# Altium schematic sheet Pin_Expansion.SchDoc(Pin_Expansion)
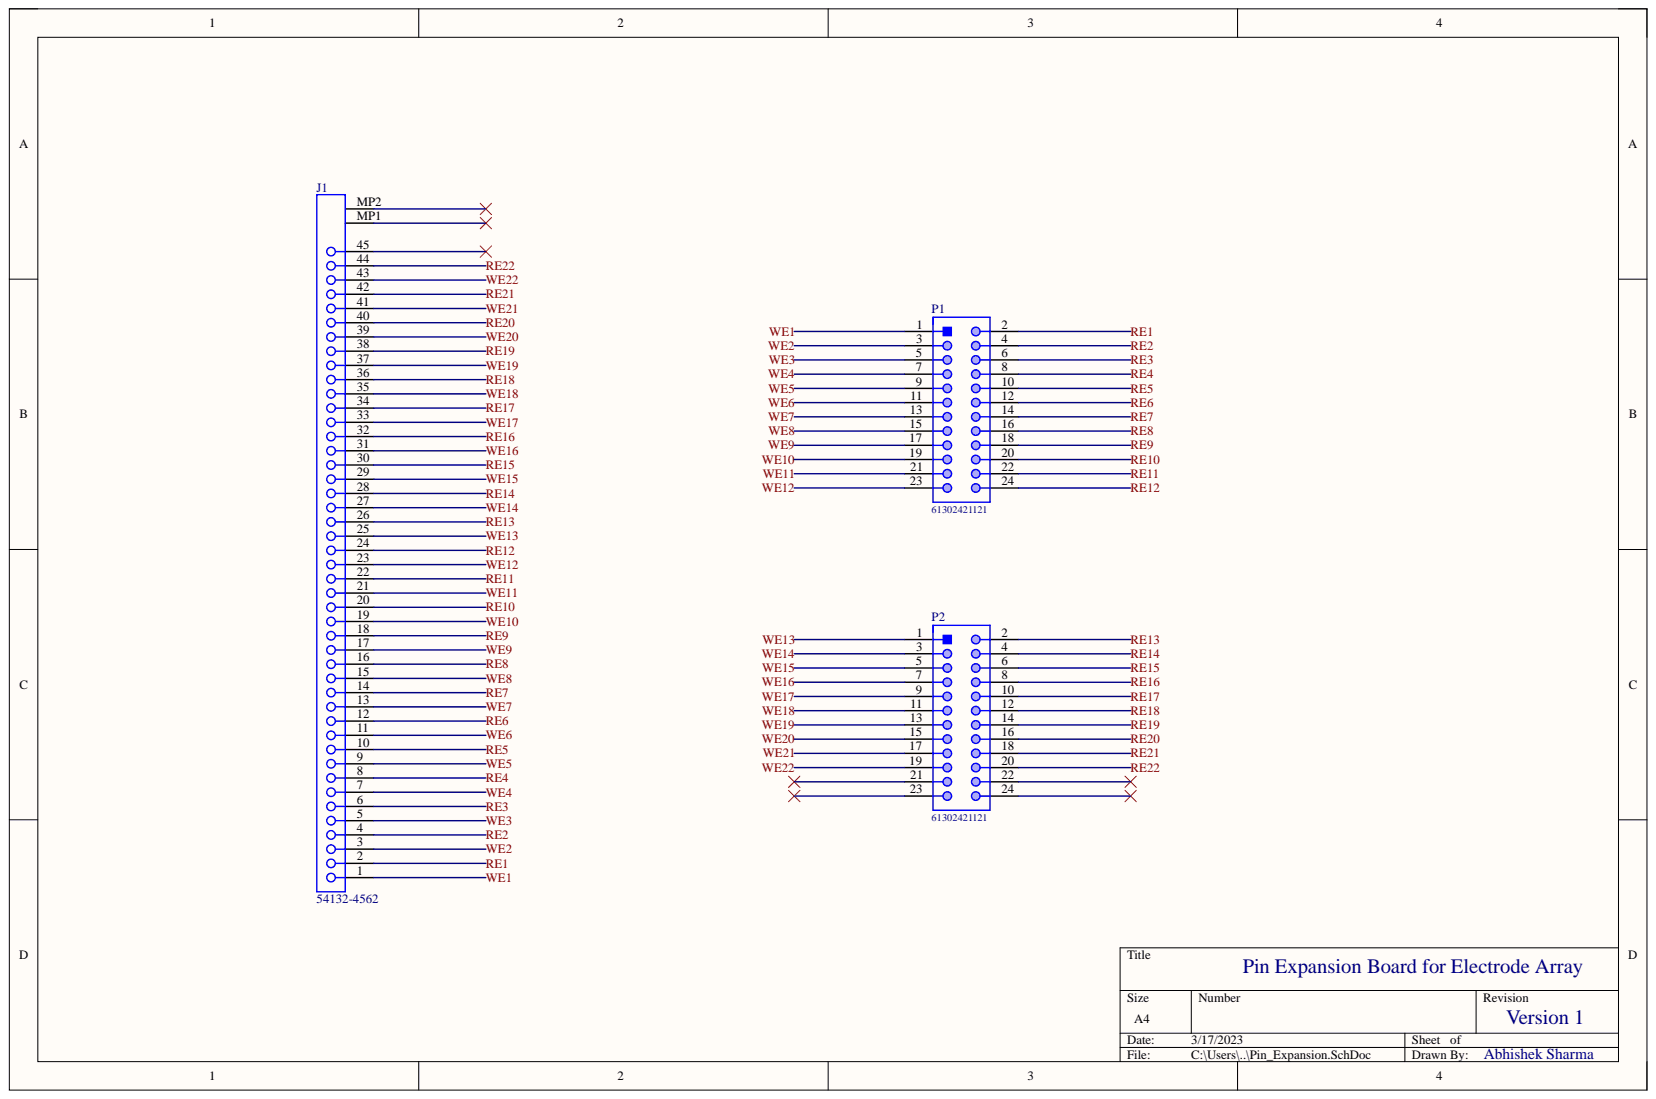
[diagram p0: Pin_Expansion.SchDoc]

## Parts
J1  54132-4562  [MOLX-54132-4562]
P1  61302421121  [61302421121]
P2  61302421121  [61302421121]

## Nets
RE1: J1.2 P1.2
RE2: J1.4 P1.4
RE3: J1.6 P1.6
RE4: J1.8 P1.8
RE5: J1.10 P1.10
RE6: J1.12 P1.12
RE7: J1.14 P1.14
RE8: J1.16 P1.16
RE9: J1.18 P1.18
RE10: J1.20 P1.20
RE11: J1.22 P1.22
RE12: J1.24 P1.24
RE13: J1.26 P2.2
RE14: J1.28 P2.4
RE15: J1.30 P2.6
RE16: J1.32 P2.8
RE17: J1.34 P2.10
RE18: J1.36 P2.12
RE19: J1.38 P2.14
RE20: J1.40 P2.16
RE21: J1.42 P2.18
RE22: J1.44 P2.20
WE1: J1.1 P1.1
WE2: J1.3 P1.3
WE3: J1.5 P1.5
WE4: J1.7 P1.7
WE5: J1.9 P1.9
WE6: J1.11 P1.11
WE7: J1.13 P1.13
WE8: J1.15 P1.15
WE9: J1.17 P1.17
WE10: J1.19 P1.19
WE11: J1.21 P1.21
WE12: J1.23 P1.23
WE13: J1.25 P2.1
WE14: J1.27 P2.3
WE15: J1.29 P2.5
WE16: J1.31 P2.7
WE17: J1.33 P2.9
WE18: J1.35 P2.11
WE19: J1.37 P2.13
WE20: J1.39 P2.15
WE21: J1.41 P2.17
WE22: J1.43 P2.19

Not connected: J1 45 MP1 MP2; P2 21 22 23 24

## Library data of the parts
J1 = CMP-1241-00535-1: 0.5 mm Pitch Easy-On(TM) Type FPC Connector, 2.0 mm Mated Height, Right Angle, ZIF, SMT, Bottom Contact, 45 Circuits, -20 to 85 degC, ELV and RoHS Compliant, Tape and Reel; Manufacturer: Molex; Circuits (Loaded): 45; Current (Max per Contact): 0.5A; Durability (mating cycles max): 20; Entry Angle: 90degrees Angle; Mated Height: 2.00mm; Material - Metal: Phosphor Bronze; Material - Plating Mating: Gold; PCB Locator: No; PCB Retention: Yes; Pitch - Mating Interface: 0.50mm; Voltage (Max): 50V; Component Kind: Standard; ComponentLink1Description: Manufacturer URL; ComponentLink1URL: http://www.molex.com/molex/index.jsp; ComponentLink2Description: Datasheet; ComponentLink2URL: http://www.molex.com/webdocs/datasheets/pdf/en-us/0541324562_FFC_FPC_CONNECTORS.pdf; ComponentLink3Description: Package Specification; ComponentLink3URL: http://www.molex.com/pdm_docs/sd/541324562_sd.pdf; Contact Position: Bottom; Library Name: Altium Content Vault; Material - Plating Termination: Gold; Mounting Technology: Surface Mount; Number of Rows: 1; Orientation: Right Angle; PackageDescription: 45-Lead FPC Connector, Pitch 0.5 mm; PackageReference: 54132-4562; PackageVersion: Rev. F, 07/2010; Packing: Tape and Reel; PartNumber: 54132-4562; Polarized to PCB: Yes; RoHS: TRUE; Stackable: No
P1 P2 = CMP-1502-01111-1: THT Vertical Pin Header WR-PHD, Pitch 2.54 mm, Dual Row, 24 pins; Manufacturer: Wurth Elektronik; Contact Resistance: 20mOhm; Gender: Male; Pin Number: 24; Pitch: 2.54mm; Rated Current: 3A; Withstanding Voltage: 500VAC; Working Voltage: 250VAC; Component Kind: Standard; Datasheet URL: https://katalog.we-online.de/em/datasheet/6130xx21121.pdf; Library Name: Altium Content Vault; Manufacturer URL: http://www.we-online.de; Mounting Technology: THT; Operating Temperature: -40to105degC; Orientation: Vertical; Package Reference: 61302421121; Packaging: Bag; Part Number: 61302421121; Row Number: Dual; Publisher: WurthElektronik
